# Altium schematic sheet JacdacKeycapButtonBc30 135.SchDoc
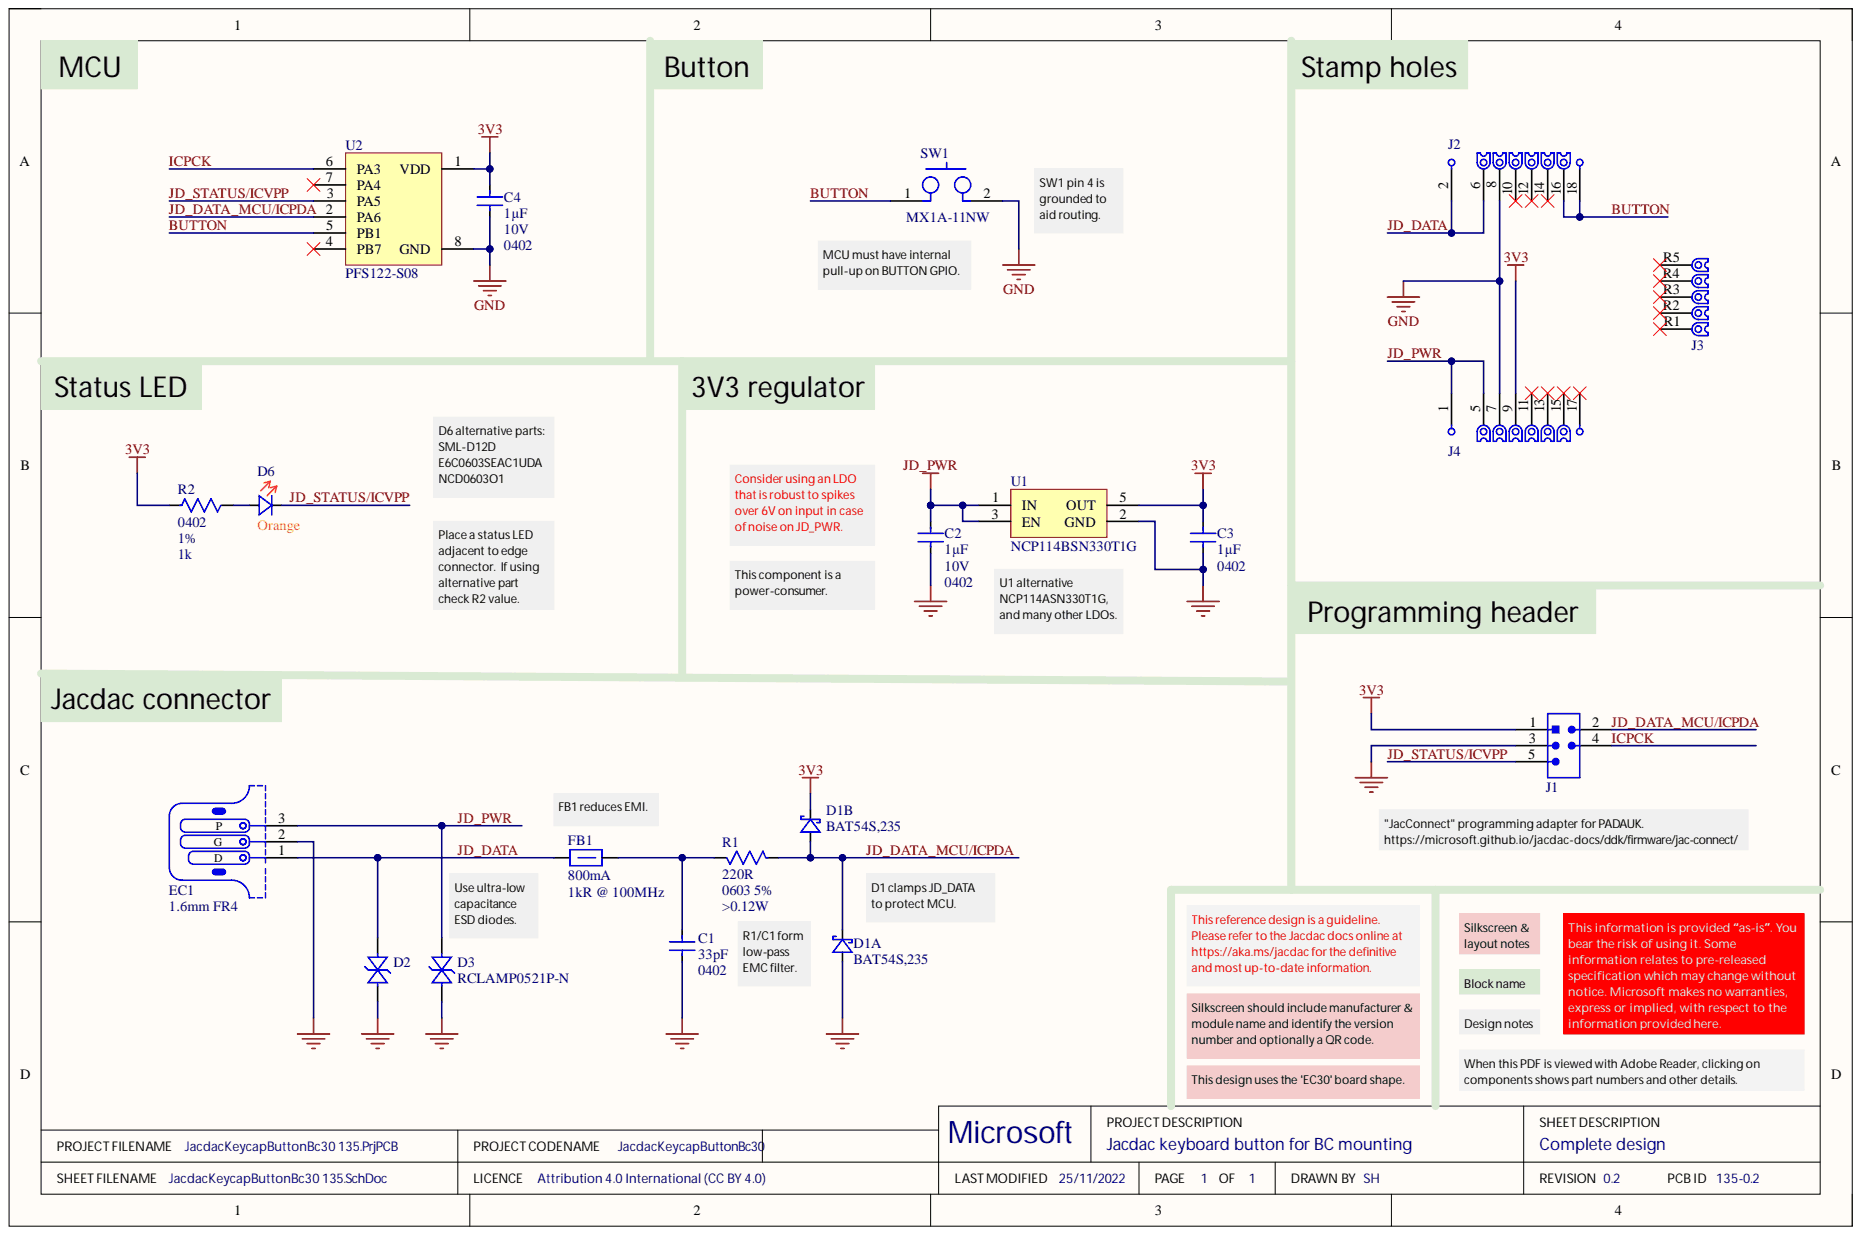
[diagram p0: JacdacKeycapButtonBc30 135.SchDoc]

## Parts
C1  Capacitance: 33pF  Case Code (Imperial): 0402
C2  Capacitance: 1µF  Case Code (Imperial): 0402  Voltage Rating: 10V
C3  Capacitance: 1µF  Case Code (Imperial): 0402
C4  Capacitance: 1µF  Case Code (Imperial): 0402  Voltage Rating: 10V
D1  Manufacturer Part Number: BAT54S,235  (parts A,B)
D2  (no value)
D3  Manufacturer Part Number: RCLAMP0521P-N
D6  Color: Orange
EC1  PCB Type: 1.6mm FR4
FB1  Current Rating: 800mA  Impedance: 1kR @ 100MHz
J1  (no value)
J2  (no value)
J3  (no value)
J4  (no value)
R1  Case Code (Imperial): 0603  Comment 1: 5%  Resistance: 220R
R2  Case Code (Imperial): 0402  Tolerance: 1%
SW1  Manufacturer Part Number 1: MX1A-11NW
U1  Manufacturer Part Number: NCP114BSN330T1G
U2  Manufacturer Part Number: PFS122-S08

## Nets
3V3: C3.2 C4.2 D1.2 J1.1 J4.9 R2.2 U1.5(OUT) U2.1(VDD)
GND: C1.1 C2.1 C3.1 C4.1 D1.1 D2.2 D3.2 EC1.2 J1.3 J2.8 J4.7 SW1.2 U1.2(GND) U2.8(GND)
BUTTON: J2.16 J2.18 SW1.1 U2.5(PB1)
ICPCK: J1.4 U2.6(PA3)
JD_DATA: D2.1 EC1.1 FB1.1 J2.2 J2.6
JD_DATA_MCU/ICPDA: D1.3 J1.2 R1.1 U2.2(PA6)
JD_PWR: C2.2 D3.1 EC1.3 J4.1 J4.5 U1.1(IN) U1.3(EN)
JD_STATUS/ICVPP: D6.1 J1.5 U2.3(PA5)
NetC1_2: C1.2 FB1.2 R1.2
NetD6_2: D6.2 R2.1

Not connected: J2 10 12 14; J3 R1 R2 R3 R4 R5; J4 11 13 15 17; U2 4(PB7) 7(PA4)

## Library data of the parts
C1 = (no symbol name): CAP CER 33PF 50V C0G/NP0 0402; Manufacturer: Samsung; Manufacturer Part Number: CL05C330JB5NNNC; Dielectric: C0G; Material: Ceramic; Temperature Coefficient: 300ppm/°C; Tolerance: 5%; Case Code (Metric): 1005; Case/Package: 0402; Category: Ceramic Capacitors; ComponentLink1Description: Online datasheet; ComponentLink1URL: https://datasheet.ciiva.com/15195/getdatasheetpartid-355282-15195687.pdf; Construction: Flat; Contact Plating: Tin; Max Operating Temperature: 125°C; Min Operating Temperature: -55°C; RoHS Compliant: Yes; Series: CL; Supplier 1: Digi-Key; Supplier 2: Newark; Supplier 3: Mouser; Supplier 4: Farnell; Supplier Part Number 1: 1276-1272-1-ND; Supplier Part Number 2: 82AC9259; Supplier Part Number 3: 187-CL05C330JB5NNNC; Supplier Part Number 4: 3013373RL; Voltage: 50V; Voltage Rating: 50V; Voltage Rating (DC): 50V
C2-C4 = (no symbol name): CAP CER 1UF 10V X5R 0402; Manufacturer: Samsung; Manufacturer Part Number: CL05A105KP5NNNC; Material: Ceramic; Tolerance: 10%; Case Code (Metric): 1005; Case/Package: 0402; Category: Capacitors; ComponentLink1Description: Online datasheet; ComponentLink1URL: https://datasheet.ciiva.com/15195/getdatasheetpartid-358390-15195630.pdf; Contact Plating: Tin; Dielectric: X5R; Max Operating Temperature: 85°C; Min Operating Temperature: -55°C; Supplier 1: Digi-Key; Supplier 2: Mouser; Supplier 3: Farnell; Supplier Part Number 1: 1276-1076-1-ND; Supplier Part Number 2: 187-CL05A105KP5NNNC; Supplier Part Number 3: 3013329
  C3: Voltage Rating: 10V
D1 = (no symbol name): DIODE ARRAY SCHOTTKY 30V SOT23; Manufacturer: Nexperia; Category: Rectifier Diodes; ComponentLink1Description: NXP datasheet online; ComponentLink1URL: https://assets.nexperia.com/documents/data-sheet/BAT54_SER.pdf; Supplier 1: Digi-Key; Supplier 2: Mouser; Supplier 3: RSComponents; Supplier Part Number 1: 1727-1868-1-ND; Supplier Part Number 2: 771-BAT54S235; Supplier Part Number 3: 1707990
D2 = (no symbol name): Bidirectional 5V (Max) 6V 16V 3.5A (8/20us) 0402 TVS RoHS; Manufacturer: Born Semiconductor; Manufacturer Part Number: RCLAMP0521P-N; ComponentLink1Description: Online datasheet; ComponentLink1URL: https://datasheet.lcsc.com/szlcsc/Bourne-Semicon-Shenzhen-RCLAMP0521P-N_C316043.pdf; Package: 0402; Supplier1: LCSC; Supplier1 Part Number: C316043
D3 = (no symbol name): Bidirectional 5V (Max) 6V 16V 3.5A (8/20us) 0402 TVS RoHS; Manufacturer: Born Semiconductor; ComponentLink1Description: Online datasheet; ComponentLink1URL: https://datasheet.lcsc.com/szlcsc/Bourne-Semicon-Shenzhen-RCLAMP0521P-N_C316043.pdf; Package: 0402; Supplier1: LCSC; Supplier1 Part Number: C316043
D6 = (no symbol name): LED ORANGE DIFFUSED 1608 SMD; Manufacturer: Everlight Elec; Manufacturer Part Number: 19-217/S2C-AQ1R1B/3T; Dominant Wavelength: 605nm; Forward Voltage: 2.05V; Lens Color: White; Luminous Intensity: 100mcd; Test Current: 25mA; Case Code (Imperial): 0603; Case Code (Metric): 1608; Case/Package: 0603; Category: LEDs; Colour: Orange; ComponentLink1Description: Online datasheet; ComponentLink1URL: https://datasheet.lcsc.com/lcsc/1912171731_Everlight-Elec-19-217-S2C-AQ1R1B-3T_C146215.pdf; Lens Transparency: Diffused; Supplier 1: LCSC; Supplier Part Number 1: C146215
EC1 = (no symbol name): 3 pin Jacdac edge connector 1.27mm pitch; Manufacturer Part Number: JACDAC_EDGE_CONNECTOR; ComponentLink1Description: Yuliang JACDAC cable connector drawing online; ComponentLink1URL: https://github.com/microsoft/jacdac-mdk/blob/main/connector/JACDAC-Connector-by-Yuliang-2020-11-28.pdf; ComponentLink2Description: JACDAC PCB edge connector spec onlin; ComponentLink2URL: https://github.com/microsoft/jacdac-mdk/blob/main/connector/JACDAC_PCB_Edge_Connector_Drawing_JD-PEC-01_rev_01.pdf
FB1 = (no symbol name): 0603 1k@100MHz 0.8A chip; Manufacturer: TDK; Manufacturer Part Number: MPZ1608S102ATA00; Core Material: Ferrite; DC Resistance (DCR): 300mR; Tolerance: 25%; Case Code (Imperial): 0603; Case Code (Metric): 1608; Case/Package: 0603; ComponentLink2Description: datasheet online; ComponentLink2URL: https://www.mouser.co.uk/datasheet/2/400/eads_commercial_power_mpz1608_en-923650.pdf; ComponentLink3Description: datasheet; Max DC Current: 800mA; Supplier 1: Digi-Key; Supplier 2: Mouser; Supplier Part Number 1: 445-8694-1-ND; Supplier Part Number 2: 810-MPZ1608S102ATA00; ComponentLink3URL: Y:\System\Altium\Libraries 2014\TDK\Datasheets\eads_commercial_power_mpz1608_en-923650.pdf
J1 = (no symbol name): Hack Connect Mini 1.27 mm pitch 2-row
J2 J4 = (no symbol name): 2.54mm, 6/8 way; Manufacturer: Generic; Manufacturer Part Number: TBD
J3 = (no symbol name): 2.54mm, 5 way; Manufacturer: Generic; Manufacturer Part Number: TBD
R1 = (no symbol name): Res Thick Film 0603 220 Ohm 1% 0.1W(1/10W) ±100ppm/°C Pad SMD T/R; Manufacturer: #NAME?; Comment 2: >0.12W; Max Power Dissipation: 100mW; Voltage Rating: 50V; Case Code (Metric): 1608; Case/Package: 0603; Category: Chip SMD Resistors; ComponentLink4Description: online datasheet; ComponentLink4URL: https://datasheet.ciiva.com/46395/443971-46395002.pdf; Construction: Rectangular; Features: Moisture Resistant; Manufacturer 1: #NAME?; Manufacturer Part Number: #NAME?; Manufacturer Part Number 1: #NAME?; Max Operating Temperature: 155°C; Min Operating Temperature: -55°C; Number of Pins: 2; Number of Terminations: 2; Power Rating: 100mW; Resistance Tolerance: 1%; Resistor Type: Thick Film; RoHS Compliant: Yes; Series: RC; Size Code: 0603; Supplier 1: Digi-Key; Supplier 2: Farnell; Supplier 3: Mouser; Supplier 4: RSComponents; Supplier Part Number 1: 311-220HRCT-ND; Supplier Part Number 2: 2421863; Supplier Part Number 3: 603-RC0603FR-07220RL; Supplier Part Number 4: 1995497; Temperature Coefficient: 100ppm/°C; Termination: SMD/SMT; Tolerance: 1%; Voltage Rating (DC): 50V
R2 = (no symbol name): RES SMD 1K OHM 1% 1/16W 0402; Manufacturer: Yageo; Manufacturer Part Number: RC0402FR-071KL; Power Rating: 62.5mW; Temperature Coefficient: 100ppm/°C; Voltage Rating: 50V; Case Code (Metric): 1005; Case/Package: 0402; Category: Resistors; ComponentLink2Description: Online datasheet; ComponentLink2URL: https://datasheet.ciiva.com/12666/pyu-rc0402-51-rohs-l-6-r-12666741.pdf; Max Operating Temperature: 155°C; Min Operating Temperature: -55°C; Number of Pins: 2; Resistance: 1k; Resistor Type: Thick Film; Supplier 1: Digi-Key; Supplier 2: Mouser; Supplier 3: Farnell; Supplier Part Number 1: 311-1.00KLRDKR-ND; Supplier Part Number 2: 603-RC0402FR-071KL; Supplier Part Number 3: 2132441; Termination: SMD/SMT; Voltage Rating (DC): 50V
SW1 = (no symbol name): Pushbutton Switch SPST-NO Keyswitch Through Hole; Manufacturer 1: Cherry; Actuator Length: 3.6mm; Actuator Type: PLUNGER; Contact Current Rating: 10mA; Contact Resistance: 200mR; Electrical Life: 50000000Cycles; Illumination Type: Illuminated; Insulation Resistance: 100MR; Max Current Rating: 100mA; Max Voltage Rating (AC): 12V; Number of Terminals/Pins: 2; Operating Force: 0.58N; Row Spacing: 6.35mm; Switch Function: Off-Mom; Throw Configuration: SPST; Case/Package: Module; Category: Pushbutton Switches; ComponentLink1Description: datasheet (offline); ComponentLink2Description: datasheet (online); ComponentLink2URL: http://www.farnell.com/datasheets/2775479.pdf; Contact Material: Gold,Silver; Contact Plating: Gold; Contact Type: 1 maker; Current Rating: 10mA; Flammability Rating: UL94 HB; Housing Material: Thermoplastic; Max Operating Temperature: 70°C; Max Voltage Rating (DC): 12V; Min Operating Temperature: -10°C; Number of Pins: 2; Orientation: Straight; RoHS Compliant: Yes; Series: MX; Supplier 1: Digi-Key; Supplier 2: Farnell; Supplier 3: Mouser; Supplier Part Number 1: CH160-ND; Supplier Part Number 2: 2292961; Supplier Part Number 3: 540-MX1A-11NW; Switching Current: 10mA; Terminal Pitch: 2.54mm; Termination: PC Pin; Voltage Rating (AC): 12V; Voltage Rating (DC): 12V; ComponentLink1URL: Y:\System\Altium\Libraries 2014\Cherry\Datasheets\MX1A-11NW.pdf
U1 = (no symbol name): CMOS Low Dropout Regulator (LDO), 300 mA 3.3V, Non-Active Discharge, TSOP5; Manufacturer: ON Semiconductor; Accuracy: 2%; Max Input Voltage: 5.5V; Max Output Current: 300mA; Min Input Voltage: 1.7V; Number of Outputs: 1; Output Type: Fixed; Polarity: Positive; Quiescent Current: 50uA; Case/Package: TSOP; Category: Voltage Regulators - Linear; ComponentLink1Description: Datasheet (online); ComponentLink1URL: http://www.farnell.com/datasheets/2118270.pdf?src-supplier=Farnell; Max Operating Temperature: 85°C; Min Operating Temperature: -40°C; Number of Pins: 5; Output Voltage: 3.3V; RoHS Compliant: Yes; Supplier 1: Digi-Key; Supplier 2: Mouser; Supplier 3: RSComponents; Supplier Part Number 1: NCP114BSN330T1GOSCT-ND; Supplier Part Number 2: 863-NCP114BSN330T1G; Supplier Part Number 3: 1263179
U2 = (no symbol name): 8bit MTP MCU with 12-bit ADC; Manufacturer: PADAUK; ComponentLink1Description: Online datasheet; ComponentLink1URL: http://www.padauk.com.tw/upload/doc/PFS122%20datasheet_EN_V001_20220518.pdf; Package: SOP-8
